# Altium schematic sheet SDCard.SchDoc(SDCard)
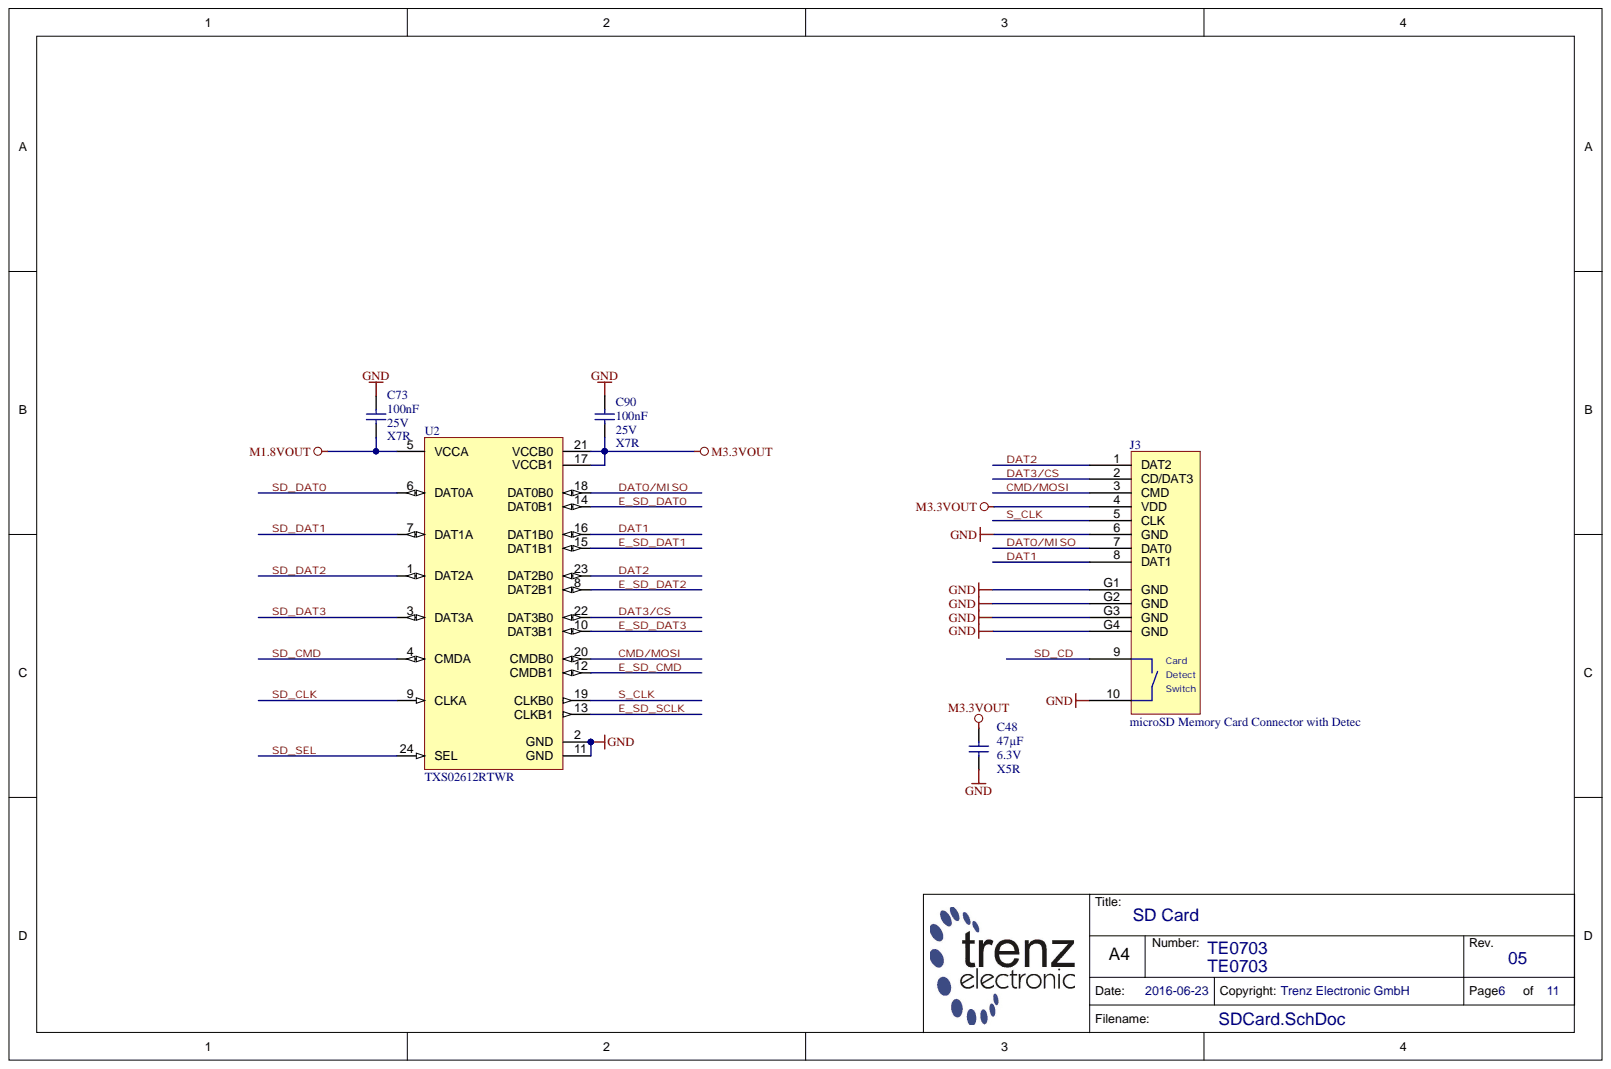
[diagram p0: SDCard.SchDoc]

## Parts
C48  47µF  Technology: X5R  Voltage: 6.3V  [CAPC3216X180N]
C73  100nF  Technology: X7R  Voltage: 25V  [CAPC1005X55N]
C90  100nF  Technology: X7R  Voltage: 25V  [CAPC1005X55N]
J3  microSD Memory Card Connector with Detec  Case: microSD  [104031-0811]
U2  TXS02612RTWR  [QFN50P400X400X80-25N-255]

## Nets
GND: C48.1 C73.2 C90.2 J3.6(GND) J3.10 J3.G1(GND) J3.G2(GND) J3.G3(GND) J3.G4(GND) U2.2(GND) U2.11(GND)
M1.8VOUT: C73.1 U2.5(VCCA)
M3.3VOUT: C48.2 C90.1 J3.4(VDD) U2.17(VCCB1) U2.21(VCCB0)
CMD/MOSI: J3.3(CMD) U2.20(CMDB0)
DAT0/MISO: J3.7(DAT0) U2.18(DAT0B0)
DAT1: J3.8(DAT1) U2.16(DAT1B0)
DAT2: J3.1(DAT2) U2.23(DAT2B0)
DAT3/CS: J3.2(CD/DAT3) U2.22(DAT3B0)
E_SD_CMD: U2.12(CMDB1)
E_SD_DAT0: U2.14(DAT0B1)
E_SD_DAT1: U2.15(DAT1B1)
E_SD_DAT2: U2.8(DAT2B1)
E_SD_DAT3: U2.10(DAT3B1)
E_SD_SCLK: U2.13(CLKB1)
S_CLK: J3.5(CLK) U2.19(CLKB0)
SD_CD: J3.9
SD_CLK: U2.9(CLKA)
SD_CMD: U2.4(CMDA)
SD_DAT0: U2.6(DAT0A)
SD_DAT1: U2.7(DAT1A)
SD_DAT2: U2.1(DAT2A)
SD_DAT3: U2.3(DAT3A)
SD_SEL: U2.24(SEL)

## Library data of the parts
C48 = 24557: KON 47µF 6.3V  X5R SMD 20%; Database Table Name: C_3216_1206; Mixed: SMT; Preferred: Yes; Value: 47u; AN: 24557; Case: 3216 (1206); Component Kind: Standard; Library Name: TE.DbLib; Tolerance: 20%
C73 C90 = 22472: KON 100nF 25V X5R SMD; Database Table Name: C_1005_0402; Mixed: SMT; Preferred: Yes; Value: 100n; AN: 22472; Case: 1005 (0402); Component Kind: Standard; Library Name: TE.DbLib
J3 = 26322: microSD Memory Card Connector with Detect Switch; Database Table Name: SOCKET; Mixed: SMT; Trenz-Stock: Yes; AN: 26322; Component Kind: Standard; H-ART: 104031-0811; Library Name: TE.DbLib; HelpURL: B:\AD\adlib\datasheet\104031-0811
U2 = 24904: Database Table Name: IF; Mixed: SMT; Trenz-Stock: Yes; AN: 24904; Case: QFN24; Component Kind: Standard; Description: TXS02612RTWR; H-ART: TXS02612RTWR; Library Name: TE.DbLib; HelpURL: B:\AD\adlib\datasheet\TXS02612
